# Altium schematic sheet KDT8253AMP-045放大器模块.SchDoc(KDT8253AMP-045放大器模块)
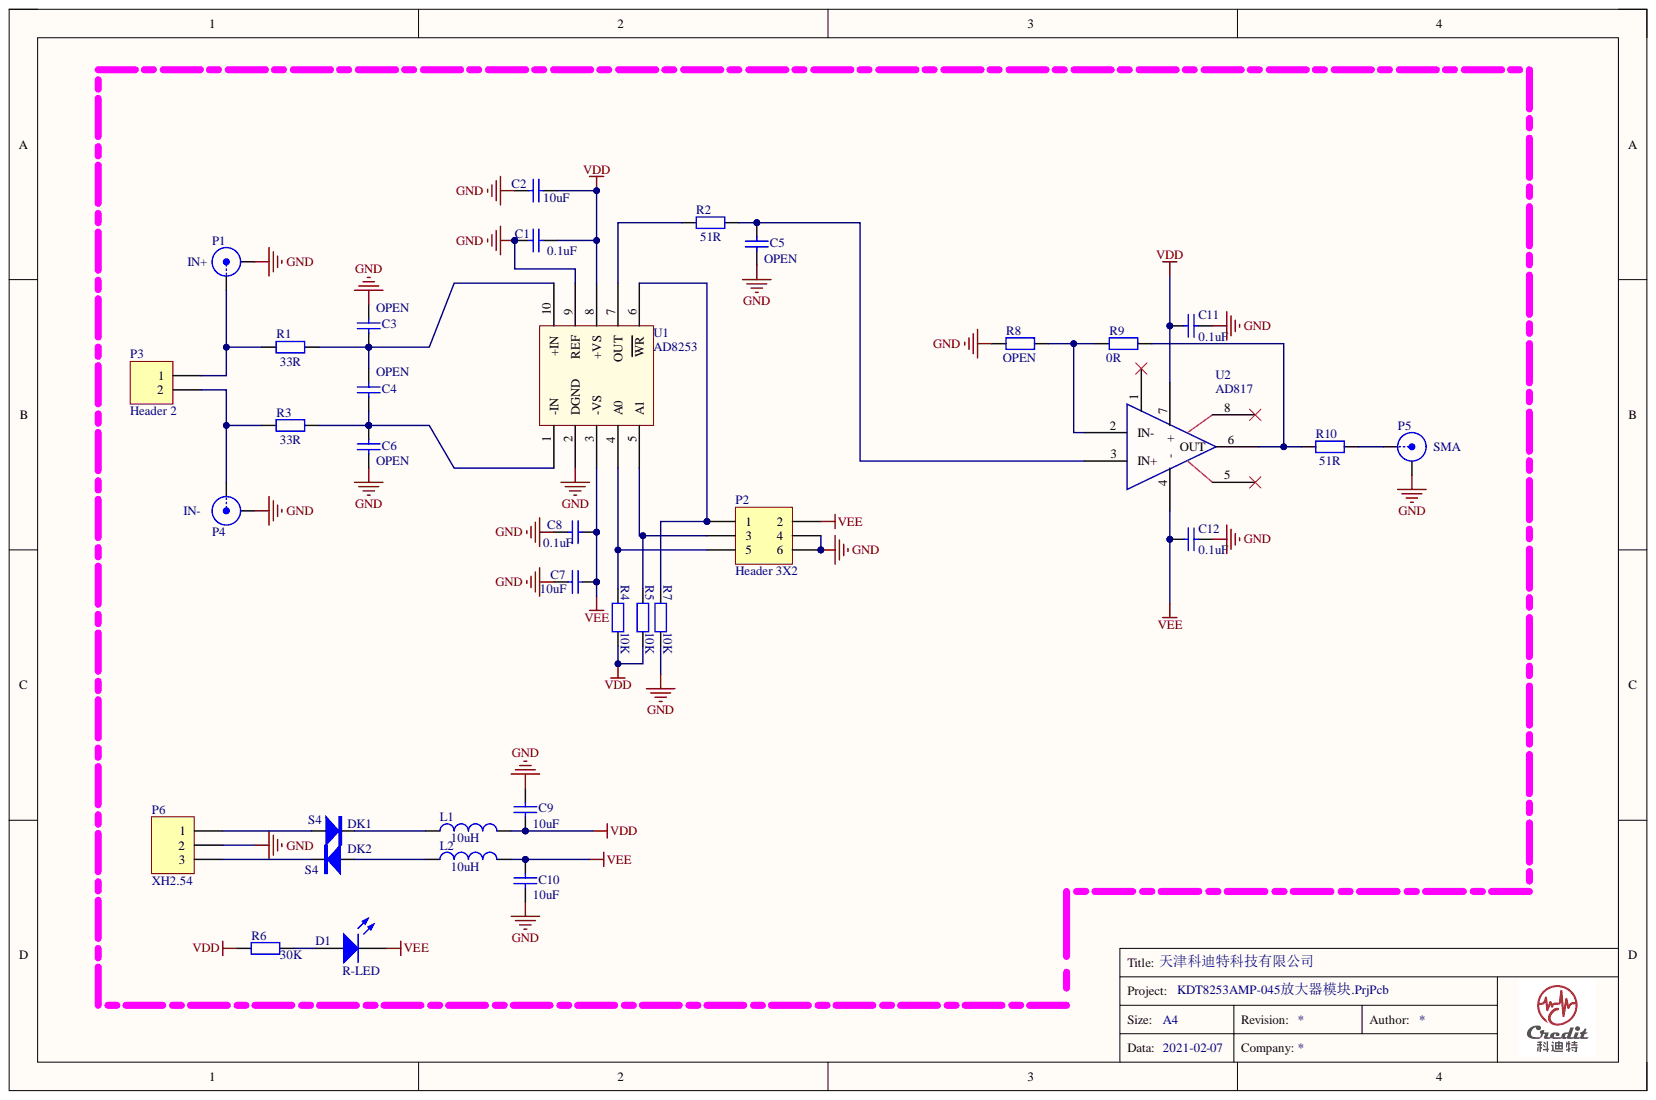
[diagram p0: KDT8253AMP-045放大器模块.SchDoc]

## Parts
C1  value 0.1uF  [C0603]
C2  value 10uF  [C 1206_L]
C3  value OPEN  [C0603]
C4  value OPEN  [C0603]
C5  value OPEN  [C0603]
C6  value OPEN  [C0603]
C7  value 10uF  [C 1206_L]
C8  value 0.1uF  [C0603]
C9  value 10uF  [C 1206_L]
C10  value 10uF  [C 1206_L]
C11  value 0.1uF  [C0603]
C12  value 0.1uF  [C0603]
D1  R-LED  [LED0805R]
DK1  S4  [SOD123]
DK2  S4  [SOD123]
L1  10uH  [R 0805_L]
L2  10uH  [R 0805_L]
P1  IN+  [SM]
P2  Header 3X2  [HDR2.54-LI-2x3P]
P3  Header 2  [3.81-2p-0]
P4  IN-  [SM]
P5  SMA  [SM]
P6  XH2.54  [XH2.54-3]
R1  value 33R  [R0603]
R2  value 51R  [R0603]
R3  value 33R  [R0603]
R4  value 10K  [R0603]
R5  value 10K  [R0603]
R6  value 30K  [R0603]
R7  value 10K  [R0603]
R8  value OPEN  [R0603]
R9  value 0R  [R0603]
R10  value 51R  [R0603]
U1  AD8253  [MSOP10_M]
U2  AD817  [SOP8]

## Nets
GND: C1.2 C2.2 C3.2 C5.1 C6.1 C7.2 C8.2 C9.2 C10.1 C11.2 C12.2 P1.2 P2.4 P2.6 P4.2 P5.2 P6.2 R7.2 R8.2 U1.2(DGND) U1.9(REF)
VDD: C1.1 C2.1 C9.1 C11.1 L1.1 R4.2 R5.2 R6.1 U1.8(+VS) U2.7(+)
VEE: C7.1 C8.1 C10.2 C12.1 D1.2 L2.1 P2.2 U1.3(-VS) U2.4(-)
NetC3_1: C3.1 C4.2 R1.1 U1.10(+IN)
NetC4_1: C4.1 C6.2 R3.1 U1.1(-IN)
NetC5_2: C5.2 R2.1 U2.3(IN+)
NetD1_1: D1.1 R6.2
NetDK1_1: DK1.1 P6.1
NetDK1_2: DK1.2 L1.2
NetDK2_1: DK2.1 L2.2
NetDK2_2: DK2.2 P6.3
NetP1_1: P1.1 P3.1 R1.2
NetP2_1: P2.1 R7.1 U1.6(WR)
NetP2_3: P2.3 R5.1 U1.5(A1)
NetP2_5: P2.5 R4.1 U1.4(A0)
NetP3_2: P3.2 P4.1 R3.2
NetP5_1: P5.1 R10.1
NetR2_2: R2.2 U1.7(OUT)
NetR8_1: R8.1 R9.2 U2.2(IN-)
NetR9_1: R9.1 R10.2 U2.6(OUT)

Not connected: U2 1 5 8

## Library data of the parts
C1-C12 = Cap: Capacitor; Component Kind: Standard; LatestRevisionDate: 17-Jul-2002; LatestRevisionNote: Re-released for DXP Platform.; Library Name: Miscellaneous Devices.IntLib; PackageDescription: Radial Cap, Thru-Hole; 2 Leads; 0.3 in Pin Spacing; PackageReference: RAD-0.3; Published: 8-Jun-2000; Signal Integrity: Cap; Simulation: CAP; Publisher: Altium Limited
D1 = LED: Component Kind: Standard; LatestRevisionDate: 08-Jul-2005; LatestRevisionNote: Mech Layer 1 removed.; PackageDescription: LED; 2 Leads; PackageReference: LED-0; Published: 8-Jun-2000; Library Name: Xiaowei.SchLib; Publisher: Altium Limited
DK1 DK2 = 1N4937: Diode; Component Kind: Standard; Simulation: 1N4937
L1 L2 = Inductor1: Inductor; Code_IEC: 1005; Value: 10mH; Code_JEITA: 0402; Component Kind: Standard; LatestRevisionDate: 29-May-2009; LatestRevisionNote: IPC-7351 Footprint Added.; Library Name: Miscellaneous Devices.SchLib; PackageDescription: Chip Inductor; PackageReference: 0402-A; Published: 8-Jun-2000; Signal Integrity: Inductor; Simulation: INDUCTOR; Publisher: Altium Limited
P1 P4 P5 = BNC: BNC Elbow Connector; Component Kind: Standard; LatestRevisionDate: 17-Jul-2002; LatestRevisionNote: Re-released for DXP Platform.; Signal Integrity: Connector; Publisher: Altium Limited
  P1 P4: Library Name: Miscellaneous Devices.SchLib
  P5: Library Name: Miscellaneous Connectors.SchLib
P2 = Header 3X2: Header, 3-Pin, Dual row; Component Kind: Standard; LatestRevisionDate: 17-Jul-2002; LatestRevisionNote: Re-released for DXP Platform.; Library Name: Miscellaneous Connectors.IntLib; Signal Integrity: Connector; Publisher: Altium Limited
P3 = Header 2: Header, 2-Pin; Component Kind: Standard; LatestRevisionDate: 17-Jul-2002; LatestRevisionNote: Re-released for DXP Platform.; Signal Integrity: Connector; Library Name: Xiaowei.SchLib; Publisher: Altium Limited
P6 = Header 3: Header, 3-Pin; Component Kind: Standard; LatestRevisionDate: 17-Jul-2002; LatestRevisionNote: Re-released for DXP Platform.; Signal Integrity: Connector; Library Name: Xiaowei.SchLib; Publisher: Altium Limited
R1-R10 = Res2: Resistor; Component Kind: Standard; LatestRevisionDate: 17-Jul-2002; LatestRevisionNote: Re-released for DXP Platform.; Library Name: Miscellaneous Devices.IntLib; PackageDescription: Axial Device, Thru-Hole; 2 Leads; 0.4 in Pin Spacing; PackageReference: AXIAL-0.4; Published: 8-Jun-2000; Signal Integrity: Res; Simulation: RESISTOR; Publisher: Altium Limited
U2 = OP07: Component Kind: Standard; Library Name: Altium Lib1.SchLib
U1 = AD8253: Component Kind: Standard; Library Name: Altium Lib1.SchLib
